# Altium schematic sheet TP_STM32_IO.SchDoc
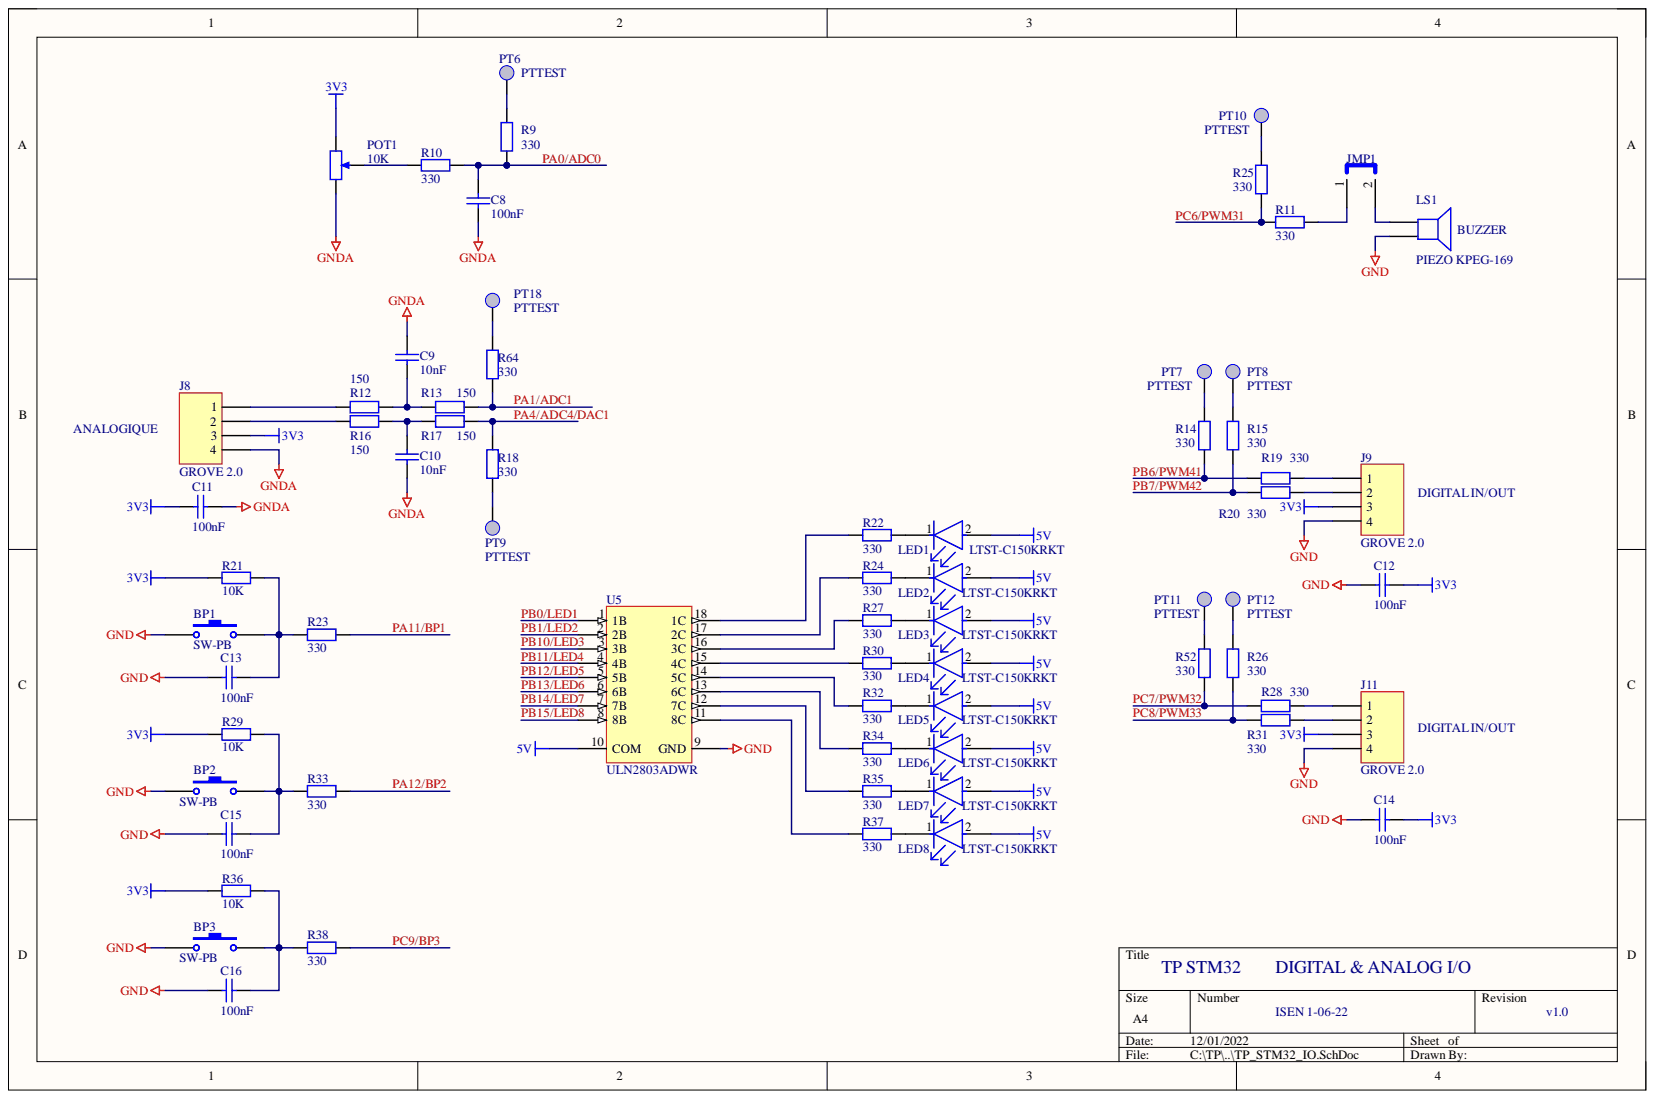
[diagram p0: TP_STM32_IO.SchDoc]

## Parts
BP1  SW-PB  [BP-MJTP12]
BP2  SW-PB  [BP-MJTP12]
BP3  SW-PB  [BP-MJTP12]
C8  100nF  [CC2012-0805]
C9  10nF  [CC2012-0805]
C10  10nF  [CC2012-0805]
C11  100nF  [CC2012-0805]
C12  100nF  [CC2012-0805]
C13  100nF  [CC2012-0805]
C14  100nF  [CC2012-0805]
C15  100nF  [CC2012-0805]
C16  100nF  [CC2012-0805]
J8  GROVE 2.0  [GROVE4-2.0]
J9  GROVE 2.0  [GROVE4-2.0]
J11  GROVE 2.0  [GROVE4-2.0]
JMP1  JUMPER  [HDR1X2]  (value not drawn)
LED1  LTST-C150KRKT  [FP-LTST-C150KRKT-MFG]
LED2  LTST-C150KRKT  [FP-LTST-C150KRKT-MFG]
LED3  LTST-C150KRKT  [FP-LTST-C150KRKT-MFG]
LED4  LTST-C150KRKT  [FP-LTST-C150KRKT-MFG]
LED5  LTST-C150KRKT  [FP-LTST-C150KRKT-MFG]
LED6  LTST-C150KRKT  [FP-LTST-C150KRKT-MFG]
LED7  LTST-C150KRKT  [FP-LTST-C150KRKT-MFG]
LED8  LTST-C150KRKT  [FP-LTST-C150KRKT-MFG]
LS1  PIEZO KPEG-169  [BUZZER]
POT1  10K  [TRIM-3386F-TW]
PT6  PTTEST  [PTEST]
PT7  PTTEST  [PTEST]
PT8  PTTEST  [PTEST]
PT9  PTTEST  [PTEST]
PT10  PTTEST  [PTEST]
PT11  PTTEST  [PTEST]
PT12  PTTEST  [PTEST]
PT18  PTTEST  [PTEST]
R9  330  [R2012-0805]
R10  330  [R2012-0805]
R11  330  [R2012-0805]
R12  150  [R2012-0805]
R13  150  [R2012-0805]
R14  330  [R2012-0805]
R15  330  [R2012-0805]
R16  150  [R2012-0805]
R17  150  [R2012-0805]
R18  330  [R2012-0805]
R19  330  [R2012-0805]
R20  330  [R2012-0805]
R21  10K  [R2012-0805]
R22  330  [R2012-0805]
R23  330  [R2012-0805]
R24  330  [R2012-0805]
R25  330  [R2012-0805]
R26  330  [R2012-0805]
R27  330  [R2012-0805]
R28  330  [R2012-0805]
R29  10K  [R2012-0805]
R30  330  [R2012-0805]
R31  330  [R2012-0805]
R32  330  [R2012-0805]
R33  330  [R2012-0805]
R34  330  [R2012-0805]
R35  330  [R2012-0805]
R36  10K  [R2012-0805]
R37  330  [R2012-0805]
R38  330  [R2012-0805]
R52  330  [R2012-0805]
R64  330  [R2012-0805]
U5  ULN2803ADWR  [DW0018A_L]

## Nets
3V3: C11.1 C12.1 C14.1 J8.3 J9.3 J11.3 POT1.1 R21.2 R29.2 R36.2
5V: LED1.2 LED2.2 LED3.2 LED4.2 LED5.2 LED6.2 LED7.2 LED8.2 U5.10(COM)
GND: BP1.1 BP2.1 BP3.1 C12.2 C13.2 C14.2 C15.1 C16.2 J9.4 J11.4 LS1.2 U5.9(GND)
GNDA: C8.2 C9.1 C10.1 C11.2 J8.4 POT1.2
PA0/ADC0: C8.1 R9.2 R10.1
PA1/ADC1: R13.1 R64.2
PA4/ADC4/DAC1: R17.1 R18.2
PA11/BP1: R23.1
PA12/BP2: R33.1
PB0/LED1: U5.1(1B)
PB1/LED2: U5.2(2B)
PB6/PWM41: R14.2 R19.1
PB7/PWM42: R15.2 R20.1
PB10/LED3: U5.3(3B)
PB11/LED4: U5.4(4B)
PB12/LED5: U5.5(5B)
PB13/LED6: U5.6(6B)
PB14/LED7: U5.7(7B)
PB15/LED8: U5.8(8B)
PC6/PWM31: R11.2 R25.2
PC7/PWM32: R28.2 R52.2
PC8/PWM33: R26.2 R31.1
PC9/BP3: R38.1
NetBP1_2: BP1.2 C13.1 R21.1 R23.2
NetBP2_2: BP2.2 C15.2 R29.1 R33.2
NetBP3_2: BP3.2 C16.1 R36.1 R38.2
NetC9_2: C9.2 R12.2 R13.2
NetC10_2: C10.2 R16.2 R17.2
NetJ8_1: J8.1 R12.1
NetJ8_2: J8.2 R16.1
NetJ9_1: J9.1 R19.2
NetJ9_2: J9.2 R20.2
NetJ11_1: J11.1 R28.1
NetJ11_2: J11.2 R31.2
NetJMP1_1: JMP1.1 R11.1
NetJMP1_2: JMP1.2 LS1.1
NetLED1_1: LED1.1 R22.2
NetLED2_1: LED2.1 R24.2
NetLED3_1: LED3.1 R27.2
NetLED4_1: LED4.1 R30.2
NetLED5_1: LED5.1 R32.2
NetLED6_1: LED6.1 R34.2
NetLED7_1: LED7.1 R35.2
NetLED8_1: LED8.1 R37.2
NetPOT1_3: POT1.3 R10.2
NetPT6_1: PT6.1 R9.1
NetPT7_1: PT7.1 R14.1
NetPT8_1: PT8.1 R15.1
NetPT9_1: PT9.1 R18.1
NetPT10_1: PT10.1 R25.1
NetPT11_1: PT11.1 R52.1
NetPT12_1: PT12.1 R26.1
NetPT18_1: PT18.1 R64.1
NetR22_1: R22.1 U5.18(1C)
NetR24_1: R24.1 U5.17(2C)
NetR27_1: R27.1 U5.16(3C)
NetR30_1: R30.1 U5.15(4C)
NetR32_1: R32.1 U5.14(5C)
NetR34_1: R34.1 U5.13(6C)
NetR35_1: R35.1 U5.12(7C)
NetR37_1: R37.1 U5.11(8C)

## Library data of the parts
BP1-BP3 = SW-PB: SWITCH BOUTON POUSSOIR APEM MJTP1212; Component Kind: Standard; Manufacturer: APEM; Published: 16/12/03; Revision: 1.0; Library Name: oxy.SCHLIB; Publisher: ISEN BREST
C8-C16 = Cap: Capacitor MLCC X7R 50V; Manufacturer: Generic Components; Class I: Passive; Class II: Capacitor; Component Kind: Standard; Library Name: Miscellaneous Devices.IntLib; Published: 8-Jun-2000; Revision: July-2002: Re-released for DXP Platform.; Signal Integrity: Cap; Simulation: CAP; Publisher: Altium Limited
  C8 C11-C16: Value: 100nF
  C9 C10: Value: 10nF
J8 = MHDR1X4: Connector GROVE 4-Pin STRAIGHT pitch 2.0mm; Manufacturer: SEEED STUDIO; Component Kind: Standard; LatestRevisionDate: 17-Jul-2002; LatestRevisionNote: Re-released for DXP Platform.; Library Name: Miscellaneous Connectors.IntLib; Signal Integrity: Connector; Publisher: Altium Limited
J9 J11 = MHDR1X4: Connector GROVE 4-Pin STRAIGHT pitch 2.0mm; Manufactuer: SEEED STUDIO; Component Kind: Standard; LatestRevisionDate: 17-Jul-2002; LatestRevisionNote: Re-released for DXP Platform.; Library Name: Miscellaneous Connectors.IntLib; Signal Integrity: Connector; Publisher: Altium Limited
JMP1 = JUMPER: JUMPER SIMPLE HE14 MALE 2cts TE 5-826936-0; Component Kind: Standard; Manufacturer: TE; Library Name: carte_pic.SchLib
LED1-LED8 = CMP-2000-06617-2: LED RED CLEAR 1206 SMD; Dominant Wavelength: 631nm; Forward Current: 30mA; Forward Voltage: 2V; Lens Color: Colorless; Lens Style: Diffused; Luminous Intensity: 54mcd; Number of Elements: 1; Power Dissipation: 62.5mW; Power Rating: 75mW; Reverse Voltage: 5V; Test Current: 20mA; Viewing Angle: 130°; Wavelength: 639nm; Case Code (Imperial): 1206; Case Code (Metric): 3216; Case/Package: 1206; Color: Red; Component Kind: Standard; Depth: 1.6mm; Height: 1.1mm; Illumination Color: Red; Lead Free: Lead Free; Length: 3.2mm; Lens Transparency: Clear; Library Name: Altium Content Vault; Max Operating Temperature: 85°C; Min Operating Temperature: -30°C; Mount: Surface Mount; Number of LEDs: 1; Number of Pins: 2; Package Quantity: 1; Packaging: Tape and Reel; Radiation Hardening: No; Width: 1.6mm
LS1 = Speaker: Buzzer pas 7.62mm; Manufacturer: KINGSTATE; Manufacturer Part Number: KEPG-169; Component Kind: Standard; LatestRevisionDate: 17-Jul-2002; LatestRevisionNote: Re-released for DXP Platform.; Library Name: Miscellaneous Devices.IntLib; PackageReference: PIN2; Published: 24-Mar-1999; Publisher: Altium Limited
POT1 = RPot2: Trimmer 1 tour BOURNS 3386F-1-103-TL; Class I: Passive; Class II: Potentiometer; Set Position: 0.5; Component Kind: Standard; Library Name: Miscellaneous Devices.IntLib; Manufacturer: BOURNS; Published: 8-Jun-2000; Revision: July-2002: Re-released for DXP Platform.; Simulation: POT; Value: 10K; Publisher: Altium Limited
PT6-PT12 PT18 = POINT-TEST: POINT TEST VERO 20-2136; Class I: Passive; Class II: Resistor; Component Kind: Standard; Library Name: STM8S.SCHLIB; Manufacturer: VERO; Published: 15/12/03; Revision: 1.0; Publisher: ISEN BREST
R9-R38 R52 R64 = Res2: Resistor; Manufacturer: Generic Components; Class I: Passive; Class II: Resistor; Component Kind: Standard; Library Name: Miscellaneous Devices.IntLib; Published: 8-Jun-2000; Revision: July-2002: Re-released for DXP Platform.; Signal Integrity: Res2; Simulation: RESISTOR; Publisher: Altium Limited
  R9-R11 R14 R15 R18-R20 R22-R28 R30-R35 R37 R38 R52 R64: Value: 330
  R12 R13 R16 R17: Value: 150
  R21 R29 R36: Value: 10K
U5 = CMP-2000-07354-1: Darlington Transistor Array, -40 to 85 degC, 18-Pin SOIC (DW), Green (RoHS & no Sb/Br), Tape and Reel; Manufacturer: Texas Instruments; Dynamic Catalog: DarlingtonArrays; IR Max: 50uA; Number of Drivers/Receivers: 8/0; Other Names: 296-15777-6; Package Version: 4040000-3/G, 01/2011; VF: 1.7V; Voltage - Supply: 5V; Category: IntegratedCircuits(ICs); Component Kind: Standard; Datasheet URL: http://www.ti.com/lit/ds/symlink/uln2803a.pdf; Datasheet Version: Rev. G, 01/2015; Family: Interface-Drivers,Receivers,Transceivers; Library Name: Altium Content Vault; Manufacturer URL: http://www.ti.com/; Mounting Technology: SurfaceMount; Mounting Type: SurfaceMount; Package / Case: 18-SOIC(0.295",7.50mmWidth); Package Description: 18-Pin Plastic Small Outline, Body 11.55 x 7.5 mm, Pitch 1.27 mm; Package Reference: DW18; Package URL: http://www.ti.com/lit/ml/mpds172a/mpds172a.pdf; Packaging: Digi-Reel®; PartNumber: ULN2803A; Protocol: -; Series: -; Standard Package: 1; Supplier Device Package: 18-SOIC; Type: DarlingtonArray
